# Altium schematic sheet Sch10_-_Flow_Sensor_-_Pump_PWM.SchDoc(Sch10_-_Flow_Sensor_-_Pump_PWM)
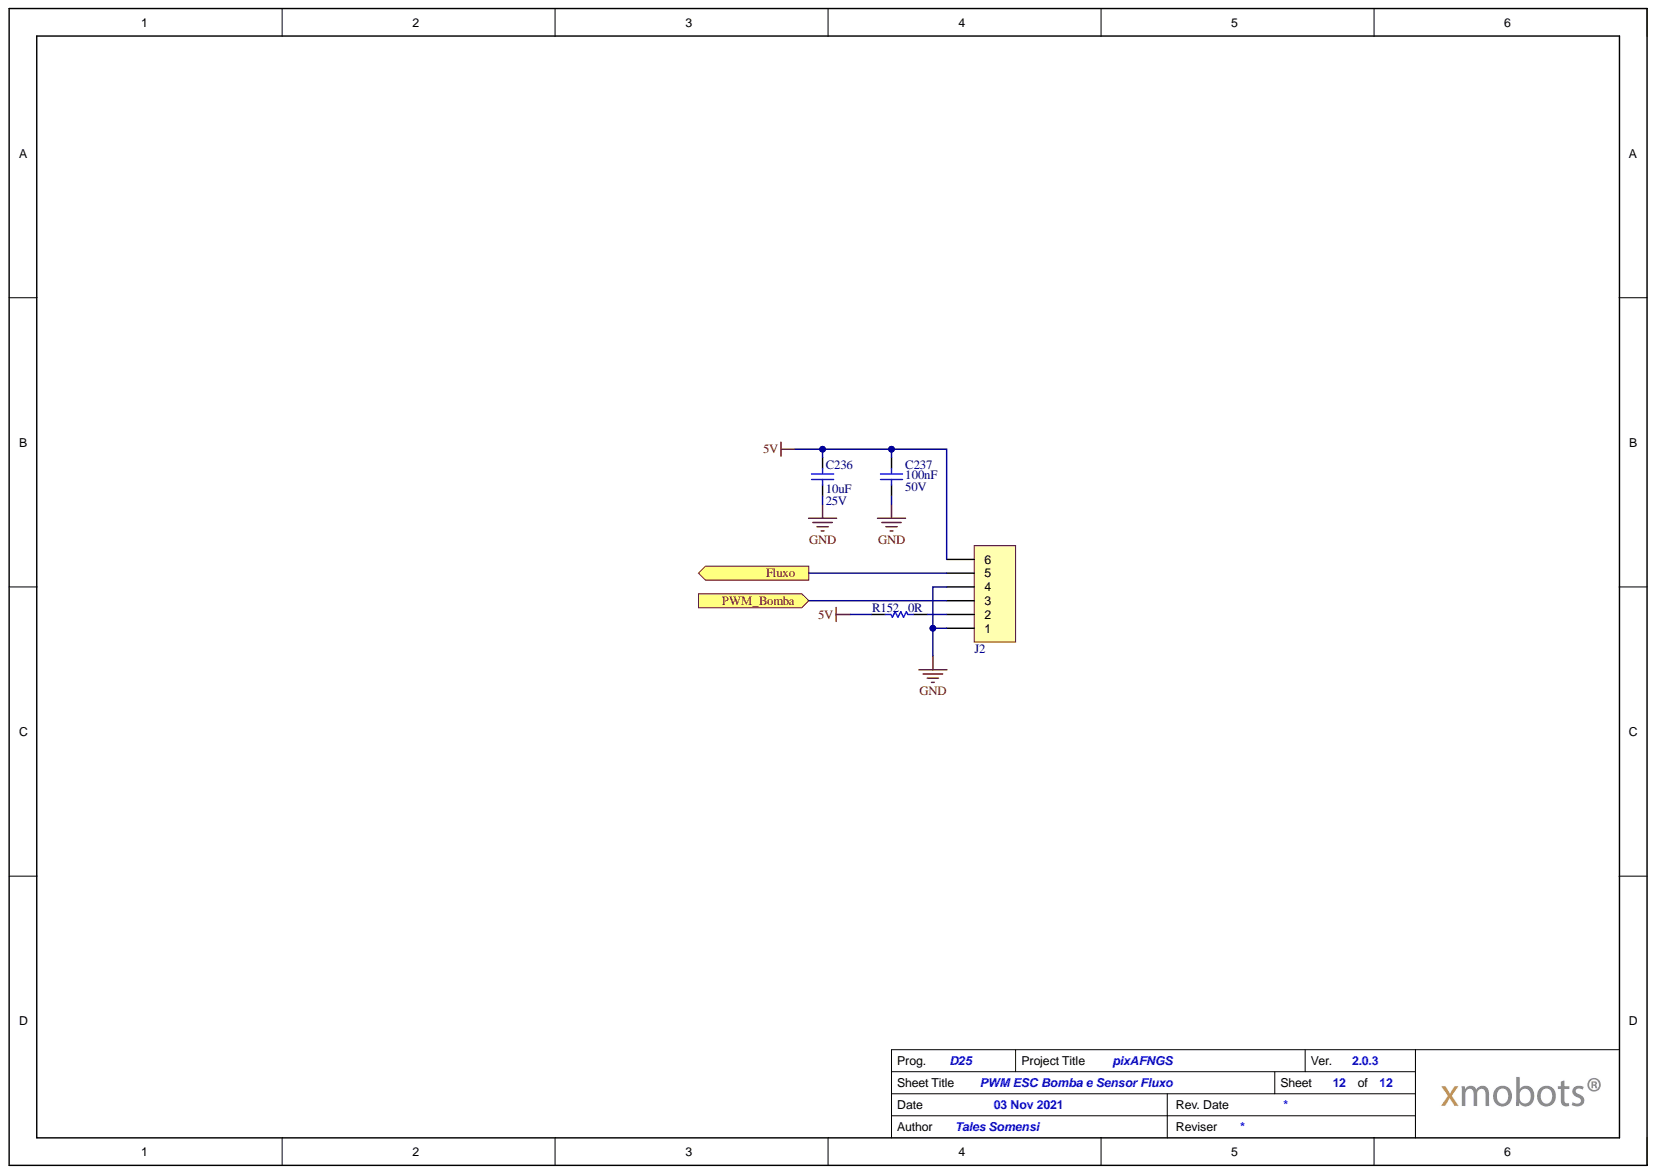
[diagram p0: Sch10_-_Flow_Sensor_-_Pump_PWM.SchDoc]

## Parts
C236  Capacitance: 10uF  Voltage: 25V  [0603]  (hidden comment: GRM188R61E106KA73J)
C237  Capacitance: 100nF  Voltage: 50V  [0603]  (hidden comment: CL10B104MB8NNNC)
J2  J-HEADER-6X1_POS-THT-2.54mm  [HEADER-6X1_POS-THT-2.54mm-180°]  (value not drawn)
R152  value 0R  [Res_0603]  (hidden comment: Res Semi)

## Ports
Fluxo, PWM_Bomba

## Nets
5V: C236.2 C237.2 J2.6 R152.1
GND: C236.1 C237.1 J2.1 J2.4
Fluxo: J2.5 ; port Fluxo
PWM_Bomba: J2.3 ; port PWM_Bomba
NetJ2_2: J2.2 R152.2

## Library data of the parts
C236 = C-CER-10uF-25V-X5R-0603: Capacitor 10uF 25V X5R 0603; Component Kind: Standard; Part Number: GRM188R61E106KA73J; Library Name: xmobots_-_comp_library.IntLib
C237 = 100nF-50V-X7R-0603: Capacitor 100nF 50V X7R 0603; Component Kind: Standard; Library Name: capacitors.SchLib; Part Number: CL10B104MB8NNNC
J2 = J-HEADER-6X1_POS-THT-2.54mm: Header, 6x1 Positions, Through Hole, 2.54mm Pitch; Component Kind: Standard; Library Name: xmobots_-_comp_library.IntLib
R152 = Res Semi: Semiconductor Resistor; Component Kind: Standard; LatestRevisionDate: 17-Jul-2002; LatestRevisionNote: Re-released for DXP Platform.; Library Name: Miscellaneous Devices.IntLib; PackageReference: AXIAL-0.5; Published: 8-Jun-2000; Signal Integrity: Res Semi; Simulation: RES; Publisher: Altium Limited
